# Altium schematic sheet RCU_v2_Peripherals.SchDoc(RCU_v2_Peripherals)
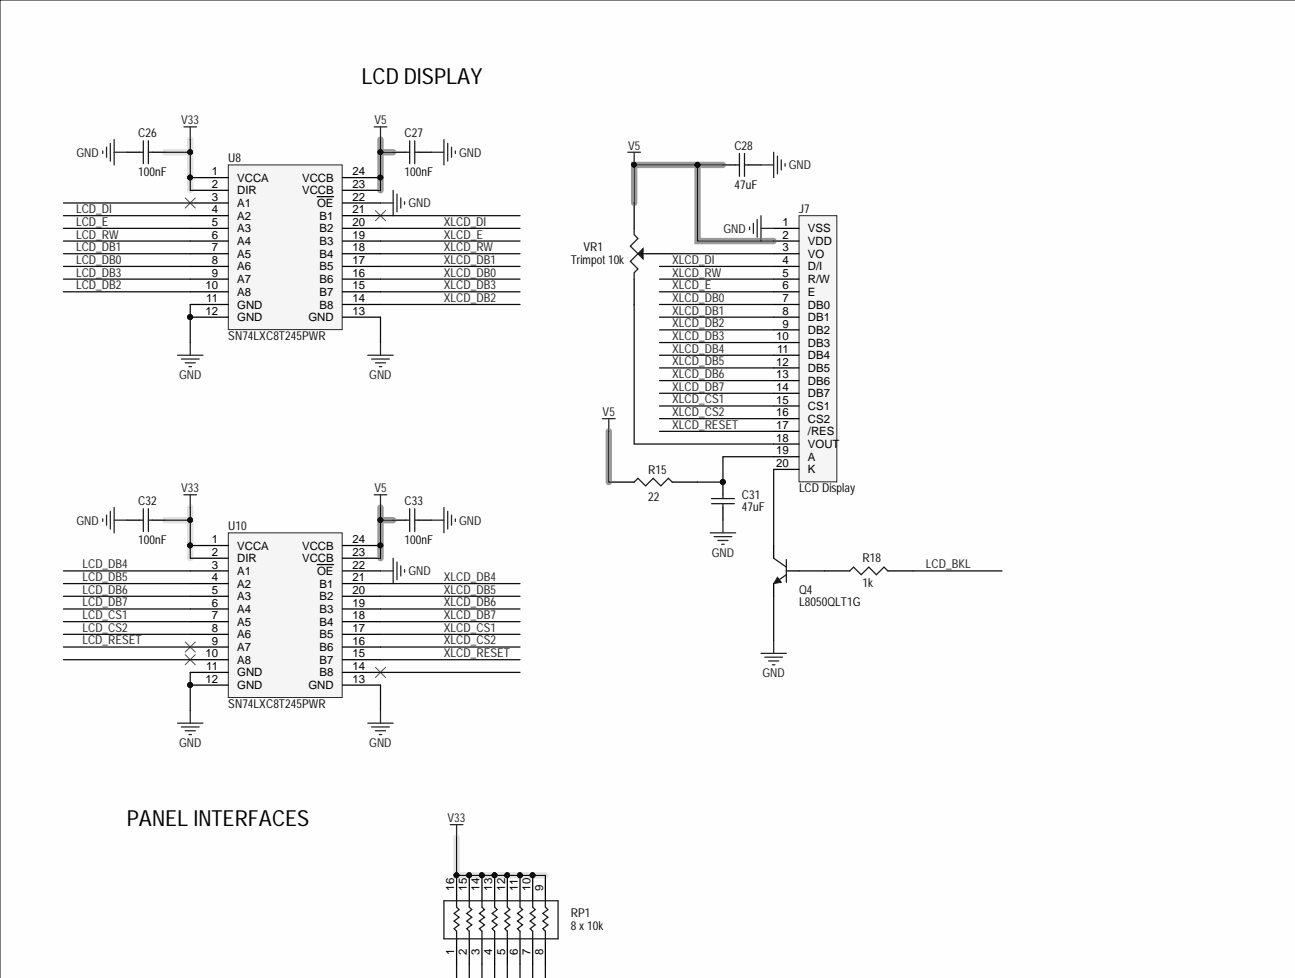
[diagram p0: RCU_v2_Peripherals.SchDoc, top left]
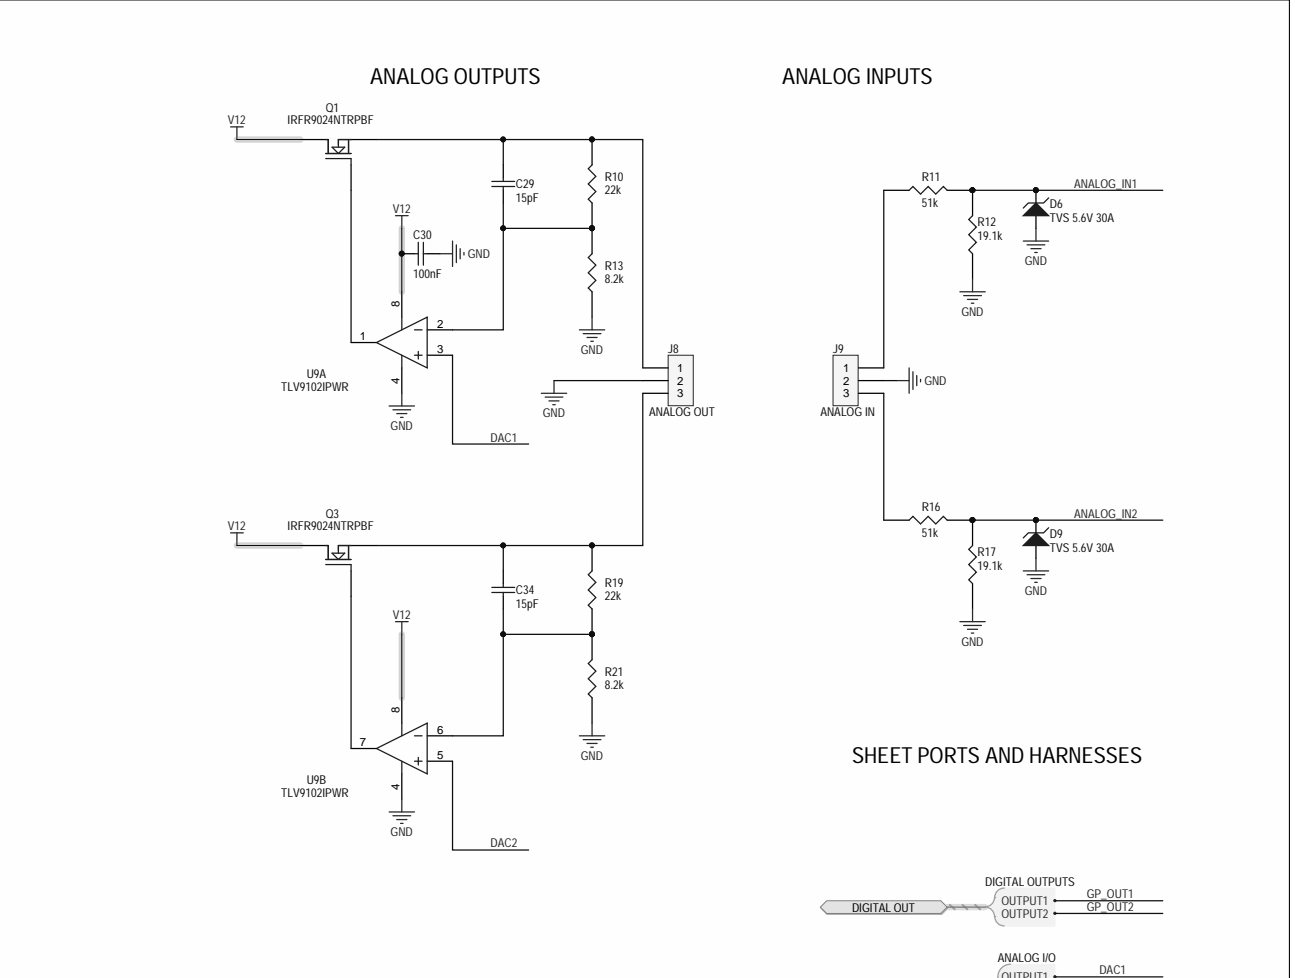
[diagram p1: RCU_v2_Peripherals.SchDoc, top right]
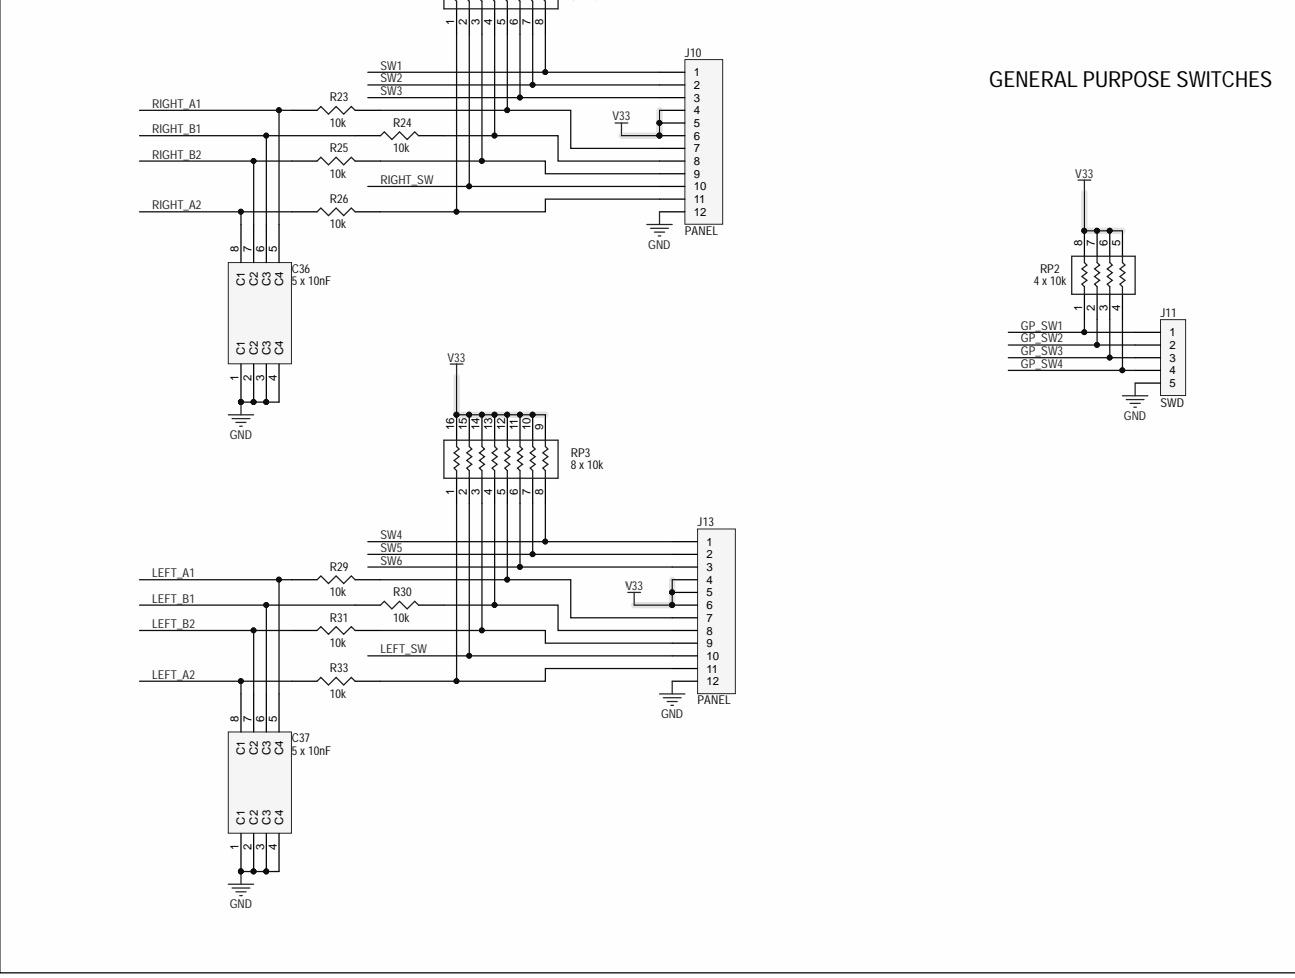
[diagram p2: RCU_v2_Peripherals.SchDoc, bottom left]
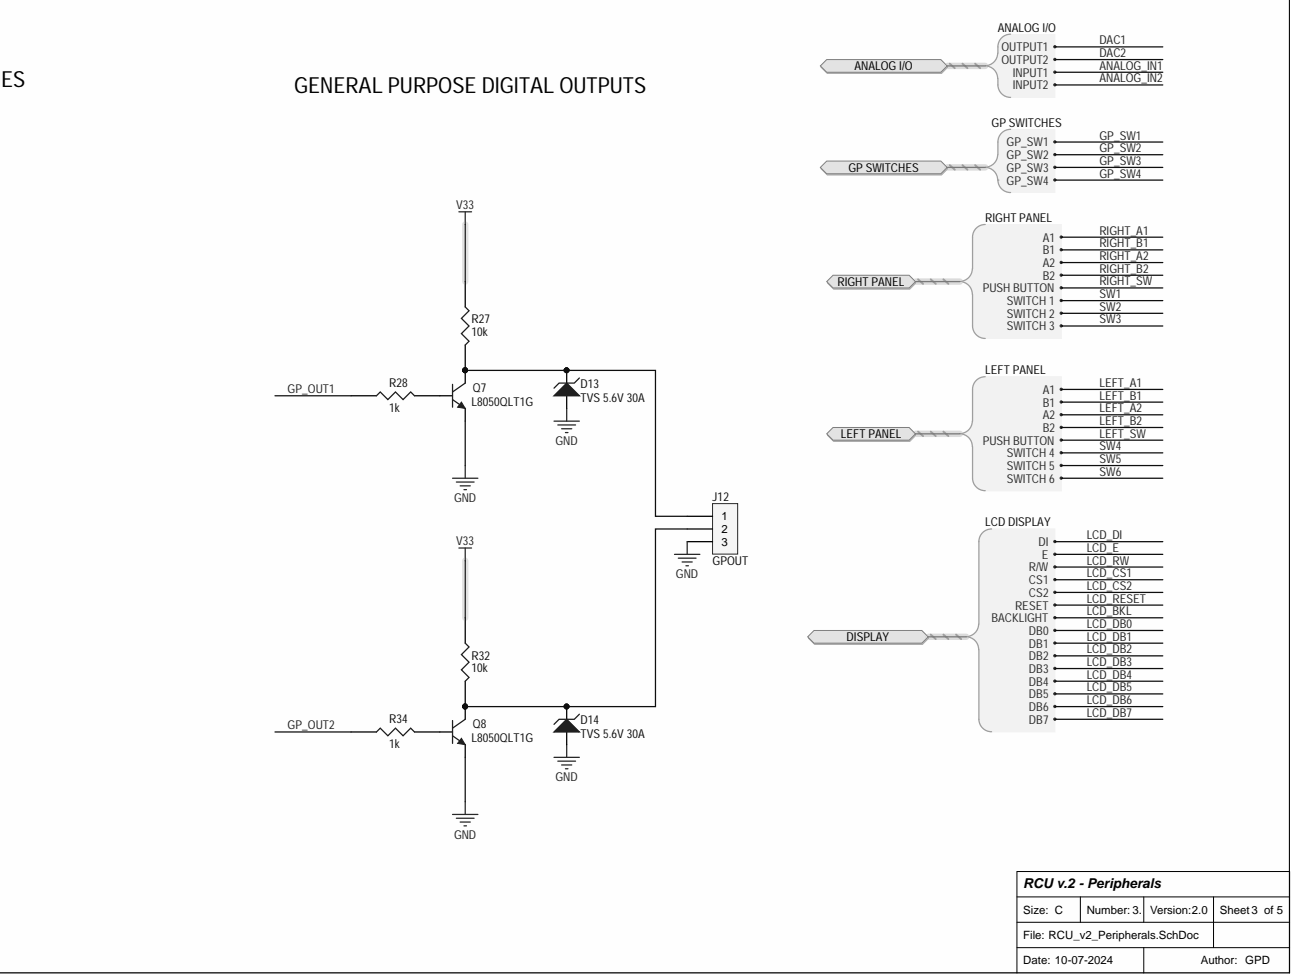
[diagram p3: RCU_v2_Peripherals.SchDoc, bottom right]

## Parts
C26  100nF  [C0402]
C27  100nF  [C0402]
C28  47uF  [C1210]
C29  15pF  [C0402]
C30  100nF  [C0402]
C31  47uF  [C1210]
C32  100nF  [C0402]
C33  100nF  [C0402]
C34  15pF  [C0402]
C36  5 x 10nF  [RESPACK08_0612]
C37  5 x 10nF  [RESPACK08_0612]
D6  TVS 5.6V 30A  [SOD523]
D9  TVS 5.6V 30A  [SOD523]
D13  TVS 5.6V 30A  [SOD523]
D14  TVS 5.6V 30A  [SOD523]
J7  LCD Display  [IDC_20_V]
J8  ANALOG OUT  [SIP3]
J9  ANALOG IN  [SIP3]
J10  PANEL  [JST-12P]
J11  SWD  [HDR1X5]
J12  GPOUT  [SIP3]
J13  PANEL  [JST-12P]
Q1  IRFR9024NTRPBF  [DPAK_123]
Q3  IRFR9024NTRPBF  [DPAK_123]
Q4  L8050QLT1G  [NPN_SMT]
Q7  L8050QLT1G  [NPN_SMT]
Q8  L8050QLT1G  [NPN_SMT]
R10  22k  [R0402]
R11  51k  [R0603]
R12  19.1k  [R0603]
R13  8.2k  [R0402]
R15  22  [R0805]
R16  51k  [R0603]
R17  19.1k  [R0603]
R18  1k  [R0402]
R19  22k  [R0402]
R21  8.2k  [R0402]
R23  10k  [R0402]
R24  10k  [R0402]
R25  10k  [R0402]
R26  10k  [R0402]
R27  10k  [R0402]
R28  1k  [R0402]
R29  10k  [R0402]
R30  10k  [R0402]
R31  10k  [R0402]
R32  10k  [R0402]
R33  10k  [R0402]
R34  1k  [R0402]
RP1  8 x 10k  [RESPACK16_0402]
RP2  4 x 10k  [RESPACK08_0603]
RP3  8 x 10k  [RESPACK16_0402]
U8  SN74LXC8T245PWR  [TSSO8X6-G24/Z7.2]
U9  TLV9102IPWR  [TSSO3X6-G8/X.3]  (parts A,B)
U10  SN74LXC8T245PWR  [TSSO8X6-G24/Z7.2]
VR1  Trimpot 10k  [POT1]

## Ports
ANALOG I/O, ANALOG I/O.INPUT1, ANALOG I/O.INPUT2, ANALOG I/O.OUTPUT1, ANALOG I/O.OUTPUT2, DIGITAL OUT, DIGITAL OUT.OUTPUT1, DIGITAL OUT.OUTPUT2, DISPLAY, DISPLAY.BACKLIGHT, DISPLAY.CS1, DISPLAY.CS2, DISPLAY.DB0, DISPLAY.DB1, DISPLAY.DB2, DISPLAY.DB3, DISPLAY.DB4, DISPLAY.DB5, DISPLAY.DB6, DISPLAY.DB7, DISPLAY.DI, DISPLAY.E, DISPLAY.R/W, DISPLAY.RESET, GP SWITCHES, GP SWITCHES.GP_SW1, GP SWITCHES.GP_SW2, GP SWITCHES.GP_SW3, GP SWITCHES.GP_SW4, LEFT PANEL, LEFT PANEL.A1, LEFT PANEL.A2, LEFT PANEL.B1, LEFT PANEL.B2, LEFT PANEL.PUSH BUTTON, LEFT PANEL.SWITCH 4, LEFT PANEL.SWITCH 5, LEFT PANEL.SWITCH 6, RIGHT PANEL, RIGHT PANEL.A1, RIGHT PANEL.A2, RIGHT PANEL.B1, RIGHT PANEL.B2, RIGHT PANEL.PUSH BUTTON, RIGHT PANEL.SWITCH 1, RIGHT PANEL.SWITCH 2, RIGHT PANEL.SWITCH 3

## Nets
GND: C26.2 C27.1 C28.1 C30.1 C31.1 C32.2 C33.1 C36.1(C1) C36.2(C2) C36.3(C3) C36.4(C4) C37.1(C1) C37.2(C2) C37.3(C3) C37.4(C4) D6.1 D9.1 D13.1 D14.1 J7.1(VSS) J8.2 J9.2 J10.12 J11.5 J12.3 J13.12 Q4.3 Q7.3 Q8.3 R12.1 R13.1 R17.1 R21.1 U8.11(GND) U8.12(GND) U8.13(GND) U8.22(OE) U9.4 U10.11(GND) U10.12(GND) U10.13(GND) U10.22(OE)
V5: C27.2 C28.2 C33.2 J7.2(VDD) R15.1 U8.23(VCCB) U8.24(VCCB) U10.23(VCCB) U10.24(VCCB) VR1.2
V12: C30.2 Q1.2 Q3.2 U9.8
V33: C26.1 C32.1 J10.4 J10.5 J10.6 J13.4 J13.5 J13.6 R27.1 R32.1 RP1.9 RP1.10 RP1.11 RP1.12 RP1.13 RP1.14 RP1.15 RP1.16 RP2.5 RP2.6 RP2.7 RP2.8 RP3.9 RP3.10 RP3.11 RP3.12 RP3.13 RP3.14 RP3.15 RP3.16 U8.1(VCCA) U8.2(DIR) U10.1(VCCA) U10.2(DIR)
ANALOG_IN1: D6.2 R11.2 R12.2 ; port ANALOG I/O
ANALOG_IN2: D9.2 R16.2 R17.2 ; port ANALOG I/O
DAC1: U9.3 ; port ANALOG I/O
DAC2: U9.5 ; port ANALOG I/O
GP_OUT1: R28.1 ; port DIGITAL OUT
GP_OUT2: R34.1 ; port DIGITAL OUT
GP_SW1: J11.1 RP2.1 ; port GP SWITCHES
GP_SW2: J11.2 RP2.2 ; port GP SWITCHES
GP_SW3: J11.3 RP2.3 ; port GP SWITCHES
GP_SW4: J11.4 RP2.4 ; port GP SWITCHES
LCD_BKL: R18.2 ; port DISPLAY
LCD_CS1: U10.7(A5) ; port DISPLAY
LCD_CS2: U10.8(A6) ; port DISPLAY
LCD_DB0: U8.8(A6) ; port DISPLAY
LCD_DB1: U8.7(A5) ; port DISPLAY
LCD_DB2: U8.10(A8) ; port DISPLAY
LCD_DB3: U8.9(A7) ; port DISPLAY
LCD_DB4: U10.3(A1) ; port DISPLAY
LCD_DB5: U10.4(A2) ; port DISPLAY
LCD_DB6: U10.5(A3) ; port DISPLAY
LCD_DB7: U10.6(A4) ; port DISPLAY
LCD_DI: U8.4(A2) ; port DISPLAY
LCD_E: U8.5(A3) ; port DISPLAY
LCD_RESET: U10.9(A7) ; port DISPLAY
LCD_RW: U8.6(A4) ; port DISPLAY
LEFT_A1: C37.5(C4) R29.1 ; port LEFT PANEL
LEFT_A2: C37.8(C1) R33.1 ; port LEFT PANEL
LEFT_B1: C37.6(C3) R30.1 ; port LEFT PANEL
LEFT_B2: C37.7(C2) R31.1 ; port LEFT PANEL
LEFT_SW: J13.10 RP3.2 ; port LEFT PANEL
RIGHT_A1: C36.5(C4) R23.1 ; port RIGHT PANEL
RIGHT_A2: C36.8(C1) R26.1 ; port RIGHT PANEL
RIGHT_B1: C36.6(C3) R24.1 ; port RIGHT PANEL
RIGHT_B2: C36.7(C2) R25.1 ; port RIGHT PANEL
RIGHT_SW: J10.10 RP1.2 ; port RIGHT PANEL
SW1: J10.1 RP1.8 ; port RIGHT PANEL
SW2: J10.2 RP1.7 ; port RIGHT PANEL
SW3: J10.3 RP1.6 ; port RIGHT PANEL
SW4: J13.1 RP3.8 ; port LEFT PANEL
SW5: J13.2 RP3.7 ; port LEFT PANEL
SW6: J13.3 RP3.6 ; port LEFT PANEL
XLCD_CS1: J7.15(CS1) U10.17(B5)
XLCD_CS2: J7.16(CS2) U10.16(B6)
XLCD_DB0: J7.7(DB0) U8.16(B6)
XLCD_DB1: J7.8(DB1) U8.17(B5)
XLCD_DB2: J7.9(DB2) U8.14(B8)
XLCD_DB3: J7.10(DB3) U8.15(B7)
XLCD_DB4: J7.11(DB4) U10.21(B1)
XLCD_DB5: J7.12(DB5) U10.20(B2)
XLCD_DB6: J7.13(DB6) U10.19(B3)
XLCD_DB7: J7.14(DB7) U10.18(B4)
XLCD_DI: J7.4(D/I) U8.20(B2)
XLCD_E: J7.6(E) U8.19(B3)
XLCD_RESET: J7.17(/RES) U10.15(B7)
XLCD_RW: J7.5(R/W) U8.18(B4)
NetC29_1: C29.1 J8.1 Q1.3 R10.2
NetC29_2: C29.2 R10.1 R13.2 U9.2
NetC31_2: C31.2 J7.19(A) R15.2
NetC34_1: C34.1 J8.3 Q3.3 R19.2
NetC34_2: C34.2 R19.1 R21.2 U9.6
NetD13_2: D13.2 J12.1 Q7.1 R27.2
NetD14_2: D14.2 J12.2 Q8.1 R32.2
NetJ7_3: J7.3(VO) VR1.3
NetJ7_18: J7.18 VR1.1
NetJ7_20: J7.20(K) Q4.1
NetJ9_1: J9.1 R11.1
NetJ9_3: J9.3 R16.1
NetJ10_7: J10.7 R23.2 RP1.5
NetJ10_8: J10.8 R24.2 RP1.4
NetJ10_9: J10.9 R25.2 RP1.3
NetJ10_11: J10.11 R26.2 RP1.1
NetJ13_7: J13.7 R29.2 RP3.5
NetJ13_8: J13.8 R30.2 RP3.4
NetJ13_9: J13.9 R31.2 RP3.3
NetJ13_11: J13.11 R33.2 RP3.1
NetQ1_1: Q1.1 U9.1
NetQ3_1: Q3.1 U9.7
NetQ4_2: Q4.2 R18.1
NetQ7_2: Q7.2 R28.2
NetQ8_2: Q8.2 R34.2

Not connected: U8 3(A1) 21(B1); U10 10(A8) 14(B8)

## Library data of the parts
C26 C27 C30 C32 C33 = CAP: 100nF ±10% 16V X7R 0402 Multilayer Ceramic Capacitors MLCC - SMD/SMT RoHS; Manufacturer Part Number: C0402C104K4RAC-TU; Manufacturer: KEMET; Value: 100nF; Supplier Part Number 3: 399-C0402C104K4RAC7867DKR-ND; Supplier Part Number 2: 8015145; Supplier Part Number 1: 80-C0402C104K4R; Supplier 3: Digikey; Supplier 2: Rs; Supplier 1: Mouser; Library Name: Miscellaneous Devices.SchLib; Component Kind: Standard
C28 C31 = CAP: Multilayer Ceramic Capacitor, 47 uF, 16 V, ± 10%, X5R, 1210 [3225 Metric]; Manufacturer Part Number: CL32A476KOJNNNE; Manufacturer: Samsung; Value: 47uF; Supplier Part Number 3: 1276-3376-1-ND; Supplier Part Number 2: C2932477; Supplier Part Number 1: 187-CL32A476KOJNNNE; Supplier 3: Digikey; Supplier 2: LCSC; Supplier 1: Mouser; Library Name: Miscellaneous Devices.SchLib; Component Kind: Standard
C29 C34 = CAP: KEMET - CBR04C150F5GAC - Capacitor, RF, 15 pF, 50 V, HiQ-CBR Series, ± 1%, 125 °C, 0402 [1005 Metric]; Value: 15pF; Supplier Part Number 3: 80-CBR04C150F5G; Supplier Part Number 2: 399-8801-1-ND; Supplier Part Number 1: 2522555; Supplier 3: Mouser; Supplier 2: Digikey; Supplier 1: Farnell; Manufacturer Part Number: CBR04C150F5GAC; Manufacturer: KEMET; Library Name: Miscellaneous Devices.SchLib; Component Kind: Standard
C36 C37 = PIC12F629/675: Cap Ceramic Array 0.01uF 100V X7R 20% 0612 SMD 4 Capacitor 125°C Paper T/R/Embossed T/R; Manufacturer Part Number: W3A41C103MAT2A; Manufacturer: Kyocera AVX; Value: 5 x 10nF; Supplier Part Number 3: 3340903RL; Supplier Part Number 2: 581-W3A41C103MAT2A; Supplier Part Number 1: 478-2871-6-ND; Supplier 3: Farnell; Supplier 2: Mouser; Supplier 1: Digikey; Library Name: PICs.SchLib; Component Kind: Standard
D6 D9 D13 D14 = 1N5380B: Epcos B72500D0050A060; Bi-Directional TVS Diode; 1000W; 2-Pin SOD-523; Manufacturer: TDK EPCOS; Value: TVS 5.6V 30A; Supplier Part Number 3: 4962968P; Supplier Part Number 2: 495-3414-6-ND; Supplier Part Number 1: C210787; Supplier 3: Rs; Supplier 2: Digikey; Supplier 1: LCSC; Simulation: 1N5380B; Manufacturer Part Number: B72500D0050A060; Library Name: DIODE.SchLib; Component Kind: Standard
J7 = CON20: Conn Shrouded Header (4 Sides) HDR 10 POS 2.54mm Solder ST Top Entry Thru-Hole Tray; Manufacturer Part Number: BHR-10-VUA; Manufacturer: Adam Equipment; Value: LCD Display; Supplier Part Number 3: BHR-10-VUA; Supplier Part Number 2: 2057-BHR-10-VUA-ND; Supplier Part Number 1: 737-BHR-10-VUA; Supplier 3: Verical; Supplier 2: Digikey; Supplier 1: Mouser; Library Name: Miscellaneous Devices.SchLib; Component Kind: Standard
J8 J9 J12 = CON3: Connector, Rcpt, 3Pos, 1Row, 2.54Mm Rohs Compliant: Yes; Manufacturer Part Number: SLW-103-01-T-S; Manufacturer: Samtec; Supplier Part Number 3: 3514986; Supplier Part Number 2: SAM1093-03-ND; Supplier Part Number 1: 200-SLW10301TS; Supplier 3: Farnell; Supplier 2: Digikey; Supplier 1: Mouser; Library Name: Miscellaneous Devices.SchLib; Component Kind: Standard
  J8: Value: ANALOG OUT
  J9: Value: ANALOG IN
  J12: Value: GPOUT
J10 J13 = CON12: Connector Header, PH Series, 12 Position, 2.00mm, Through Hole, Press-Fit, Solder, Natural; Manufacturer Part Number: B12B-PH-K-S(LF)(SN); Manufacturer: JST; Value: PANEL; Supplier Part Number 2: C158028; Supplier Part Number 1: 9492470; Supplier 2: LCSC; Supplier 1: Farnell; Library Name: Miscellaneous Devices.SchLib; Component Kind: Standard
J11 = CON5: Free pads - do not populate; Value: SWD; Supplier Part Number 3: N/A; Supplier Part Number 2: N/A; Supplier Part Number 1: N/A; Supplier 3: N/A; Supplier 2: N/A; Supplier 1: N/A; Manufacturer Part Number: N/A; Manufacturer: N/A; Library Name: Miscellaneous Devices.SchLib; Component Kind: Standard
Q1 Q3 = MOSFET N: Mosfet, Power; P-ch; Vdss -55V; Rds(on) 0.175 Ohm; Id -11A; D-pak (TO-252AA); Pd 38W; Manufacturer: Infineon; Value: IRFR9024NTRPBF; Supplier Part Number 3: IRFR9024NPBFDKR-ND; Supplier Part Number 2: 942-IRFR9024NTRPBF; Supplier Part Number 1: C2585; Supplier 3: Digikey; Supplier 2: Mouser; Supplier 1: LCSC; Manufacturer Part Number: IRFR9024NTRPBF; Library Name: Miscellaneous Devices.SchLib; Component Kind: Standard
Q4 Q7 Q8 = MMBT3904: 25V 225mW 800mA NPN SOT-23 Bipolar Transistors - BJT ROHS; Manufacturer: LRC; Value: L8050QLT1G; Supplier Part Number 3: C49581; Supplier Part Number 2: C49581; Supplier Part Number 1: C49581; Supplier 3: LCSC; Supplier 2: LCSC; Supplier 1: LCSC; Simulation: MMBT3904; Manufacturer Part Number: L8050QLT1G; Component Kind: Standard
R10 R19 = RES1: Res Thin Film 0402 22K Ohm 0.1% 0.063W(1/16W) ±25ppm/C Pad SMD T/R; Manufacturer Part Number: RT0402BRD0722KL; Manufacturer: Yageo; Value: 22k; Supplier Part Number 3: 603-RT0402BRD0722KL; Supplier Part Number 2: YAG4210DKR-ND; Supplier Part Number 1: C326601; Supplier 3: Mouser; Supplier 2: Digikey; Supplier 1: LCSC; Component Kind: Standard
R11 R16 = RES1: SMD Chip Resistor, 51 kOhm, ± 5%, 100 mW, 0603 [1608 Metric], Thick Film, General Purpose; Manufacturer Part Number: RC0603JR-0751KL; Manufacturer: Yageo; Value: 51k; Supplier Part Number 3: 603-RC0603JR-0751KL; Supplier Part Number 2: 311-51KGRDKR-ND; Supplier Part Number 1: C107232; Supplier 3: Mouser; Supplier 2: Digikey; Supplier 1: LCSC; Component Kind: Standard
R12 R17 = RES1: SMD Chip Resistor, 19.1 kOhm, ± 1%, 100 mW, 0603 [1608 Metric], Thick Film, General Purpose; Manufacturer Part Number: RC0603FR-0719K1L; Manufacturer: Yageo; Value: 19.1k; Supplier Part Number 3: 311-19.1KHRDKR-ND; Supplier Part Number 2: 603-RC0603FR-0719K1L; Supplier Part Number 1: C173754; Supplier 3: Digikey; Supplier 2: Mouser; Supplier 1: LCSC; Component Kind: Standard
R13 R21 = RES1: SMD Chip Resistor, 8.2 kOhm, ± 0.1%, 63 mW, 0402 [1005 Metric], Thin Film, High Precision High Stability; Manufacturer Part Number: RT0402BRD078K2L; Manufacturer: Yageo; Value: 8.2k; Supplier Part Number 3: 3496540; Supplier Part Number 2: 603-RT0402BRD078K2L; Supplier Part Number 1: YAG4318DKR-ND; Supplier 3: Farnell; Supplier 2: Mouser; Supplier 1: Digikey; Component Kind: Standard
R15 = RES1: SMD Chip Resistor, 22 Ohm, ± 1%, 125 mW, 0805 [2012 Metric], Thin Film, High Precision High Stability; Manufacturer Part Number: RT0805FRE0722RL; Manufacturer: Yageo; Value: 22; Supplier Part Number 3: 603-RT0805FRE0722RL; Supplier Part Number 2: 13-RT0805FRE0722RLCT-ND; Supplier Part Number 1: 13-RT0805FRE0722RLDKR-ND; Supplier 3: Mouser; Supplier 2: Digikey; Supplier 1: Digikey; Component Kind: Standard
R18 R28 R34 = RES1: SMD Chip Resistor, 1 kOhm, ± 1%, 63 mW, 0402 [1005 Metric], Thick Film, General Purpose; Manufacturer Part Number: CRCW04021K00FKED; Manufacturer: Vishay Dale; Value: 1k; Supplier Part Number 3: C71623; Supplier Part Number 2: 71-CRCW0402-1.0K-E3; Supplier Part Number 1: 541-1.00KLDKR-ND; Supplier 3: LCSC; Supplier 2: Mouser; Supplier 1: Digikey; Component Kind: Standard
R23-R27 R29-R33 = RES1: SMD Chip Resistor, 10 kOhm, ± 5%, 63 mW, 0402 [1005 Metric], Thick Film, General Purpose; Manufacturer Part Number: RT0402BRE0710KL; Manufacturer: Yageo; Value: 10k; Supplier Part Number 3: YAG2305CT-ND; Supplier Part Number 2: YAG2305DKR-ND; Supplier Part Number 1: 603-RT0402BRE0710KL; Supplier 3: Digikey; Supplier 2: Digikey; Supplier 1: Mouser; Library Name: Miscellaneous Devices.SchLib; Component Kind: Standard
RP1 RP3 = RESPACK3: Res Thick Film Array 10K Ohm 5% 1/4W ±200ppm/°C ISOL Molded 16-Pin 1506(8 X 0402) Convex SMD Punched Carrier T/R; Manufacturer Part Number: EXB-2HV103JV; Manufacturer: Panasonic; Value: 8 x 10k; Supplier Part Number 3: Y1103DKR-ND; Supplier Part Number 2: C713454; Supplier Part Number 1: 667-EXB-2HV103JV; Supplier 3: Digikey; Supplier 2: LCSC; Supplier 1: Mouser; Library Name: Miscellaneous Devices.SchLib; Component Kind: Standard
RP2 = RES_ARRAY4: Resistor array x 4 , isolated; Manufacturer Part Number: YC164-JR-0710KL; Manufacturer: Yageo; Value: 4 x 10k; Supplier Part Number 3: YC164J-10KDKR-ND; Supplier Part Number 2: 603-YC164-JR-0710KL; Supplier Part Number 1: C107374; Supplier 3: Digikey; Supplier 2: Mouser; Supplier 1: LCSC; Component Kind: Standard; Library Name: Gonzalo.IntLib
U8 U10 = SN74LXC8T245: 8-bit dual-supply bus transceiver with configurable voltage-level shifting and three-state outputs 24-TSSOP -40 to 125; Manufacturer: Texas Instruments; Value: SN74LXC8T245PWR; Supplier Part Number 3: SN74LXC8T245PWR; Supplier Part Number 2: 296-SN74LXC8T245PWRDKR-ND; Supplier Part Number 1: 595-SN74LXC8T245PWR; Supplier 3: Texas Instruments; Supplier 2: Digikey; Supplier 1: Mouser; Manufacturer Part Number: SN74LXC8T245PWR; Library Name: ICs.SchLib; ComponentLink1URL: https://www.ti.com/lit/ds/symlink/sn74lxc8t245.pdf; ComponentLink1Description: Datasheet; Component Kind: Standard
U9 = LM358M(8): Low Power Operational Amplifier 2 Channel Rail-to-Rail Input/Output 2.7V to 16V 1.1MHz GBW 8-Pin TSSOP T/R; Manufacturer: Texas Instruments; Value: TLV9102IPWR; Supplier Part Number 3: 595-TLV9102IPWR; Supplier Part Number 2: 296-TLV9102IPWRCT-ND; Supplier Part Number 1: TLV9102IPWR; Supplier 3: Mouser; Supplier 2: Digikey; Supplier 1: Texas Instruments; Simulation: OPA2314; Manufacturer Part Number: TLV9102IPWR; Library Name: NSC Operational Amplifier.SchLib; ComponentLink1URL: https://www.ti.com/lit/ds/symlink/tlv9102.pdf; ComponentLink1Description: Datasheet; Component Kind: Standard
VR1 = POT1: Cermet trimmer potentiometer, 10 kΩ, 0.5 W, THT, on top; Manufacturer Part Number: 3362P-1-103LF; Manufacturer: Bourns; Value: Trimpot 10k; Supplier Part Number 3: C58159; Supplier Part Number 2: 652-3362P-1-103LF; Supplier Part Number 1: 3362P-103LF-ND; Supplier 3: LCSC; Supplier 2: Mouser; Supplier 1: Digikey; Library Name: Miscellaneous Devices.SchLib; Component Kind: Standard
